# Altium schematic sheet pt_boy_revB.SchDoc
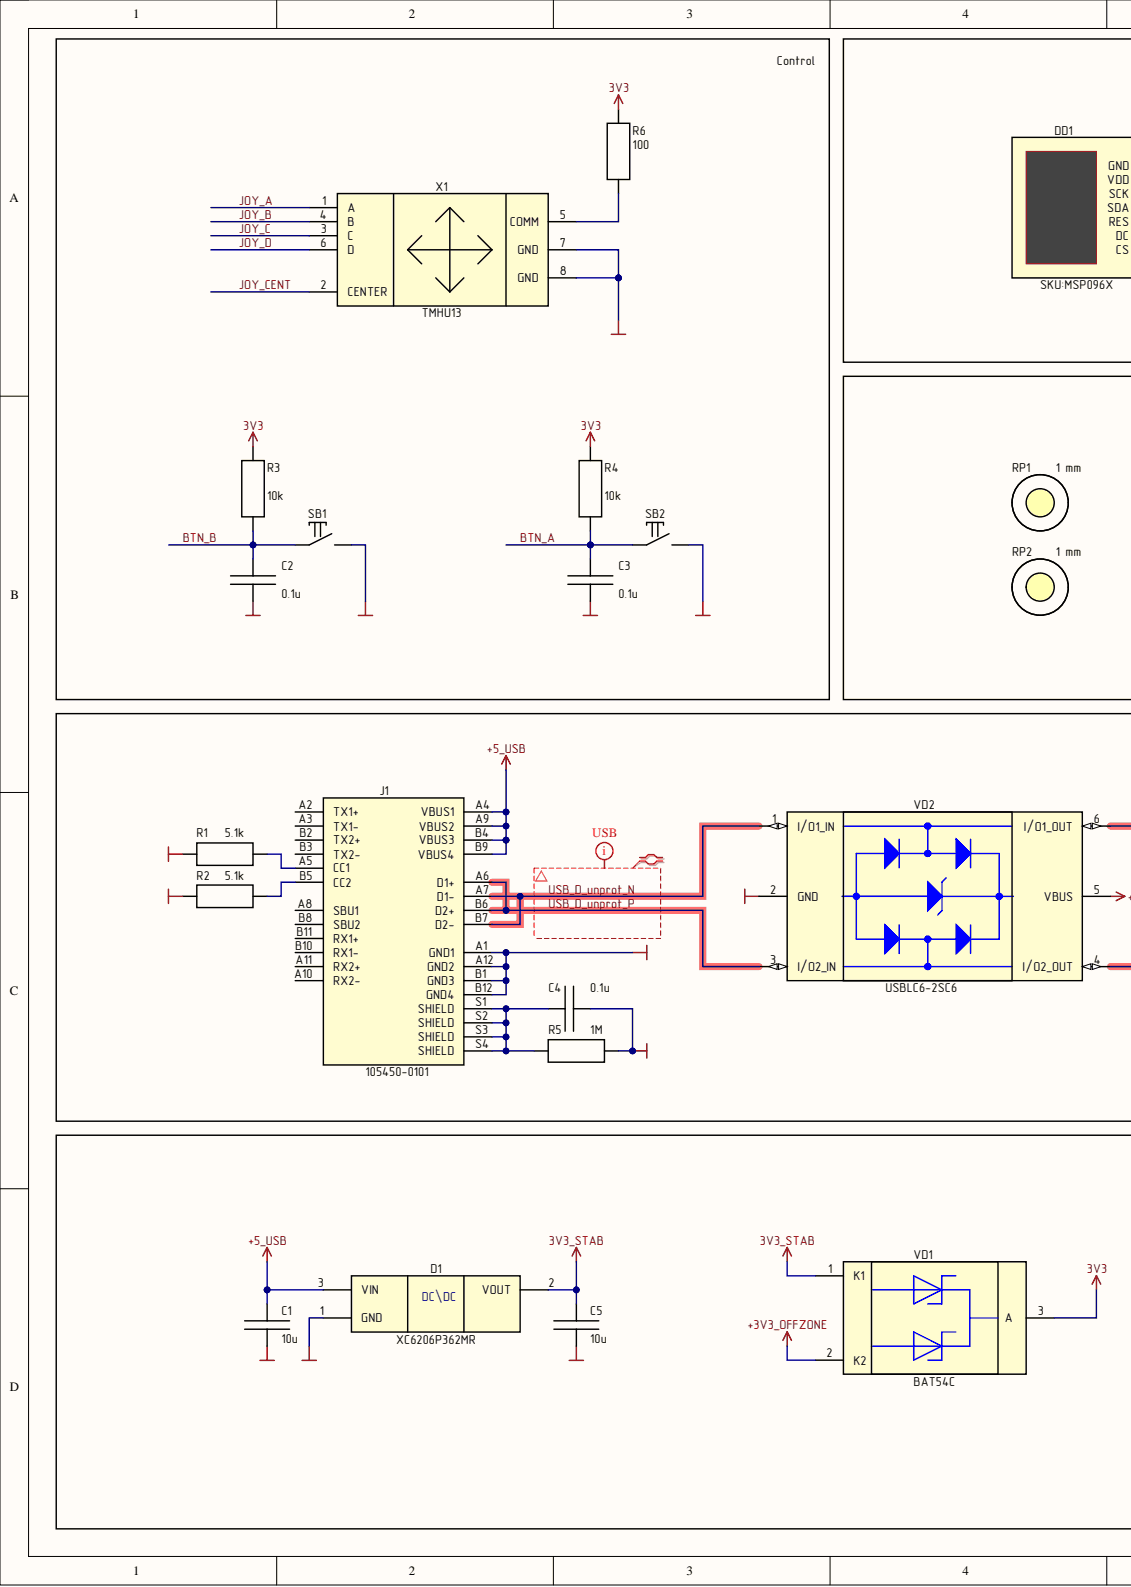
[diagram p0: pt_boy_revB.SchDoc, left]
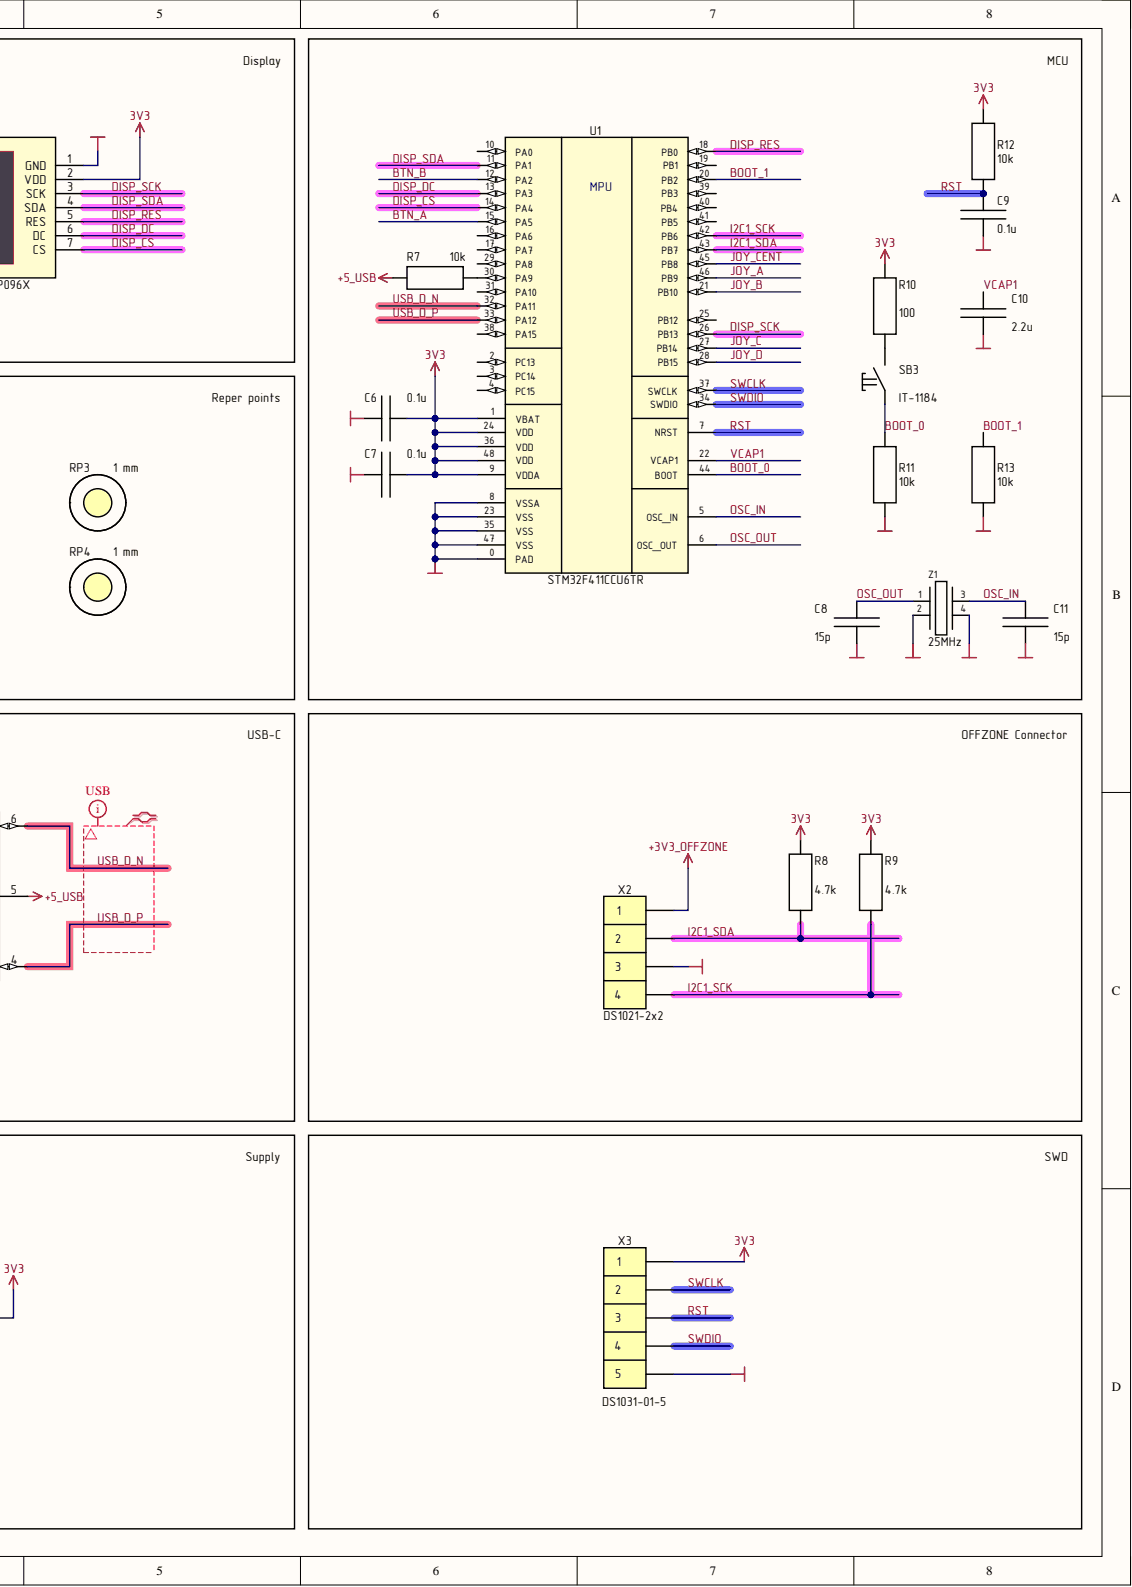
[diagram p1: pt_boy_revB.SchDoc, right]

## Parts
C1  10u  [CH0603C]
C2  0.1u  [CH0603C]
C3  0.1u  [CH0603C]
C4  0.1u  [CH0603C]
C5  10u  [CH0603C]
C6  0.1u  [CH0603C]
C7  0.1u  [CH0603C]
C8  15p  [CH0603C]
C9  0.1u  [CH0603C]
C10  2.2u  [CH0603C]
C11  15p  [CH0603C]
D1  XC6206P362MR  [SOT23_132]
DD1  SKU:MSP096X  [OLED I2C and SPI]
J1  105450-0101  [MOLEX_105450-0101]
R1  5.1k  [CH0603R]
R2  5.1k  [CH0603R]
R3  10k  [CH0603R]
R4  10k  [CH0603R]
R5  1M  [CH0603R]
R6  100  [CH0603R]
R7  10k  [CH0603R]
R8  4.7k  [CH0603R]
R9  4.7k  [CH0603R]
R10  100  [CH0603R]
R11  10k  [CH0603R]
R12  10k  [CH0603R]
R13  10k  [CH0603R]
RP1  1 mm  [REPER-10]  (no BOM)
RP2  1 mm  [REPER-10]  (no BOM)
RP3  1 mm  [REPER-10]  (no BOM)
RP4  1 mm  [REPER-10]  (no BOM)
SB1  BTN_B  [DTSM-62]  (value not drawn)
SB2  BTN_A  [DTSM-62]  (value not drawn)
SB3  BOOT  [IT-1184]
U1  IC microcontroller, 48-UFQFPN (7x7), 32-Bit, Cortex-M4, 256KB, 128KB, 100MHz, 2...3.6V, -40...+85°C  [BODY: UFQFPN48 (7x7) - via 0.2mm]  (value not drawn)
VD1  BAT54C  [SOT23_132]
VD2  USBLC6-2SC6  [SOT95P280X145-6N]
X1  TMHU13  [TMHU13]
X2  DS1021-2x2  [DS1021-2x2]
X3  DS1031-01-5  [DS1031-01-5]  (no BOM)
Z1  Frequency: 25MHz  [OSC: 3225]  (hidden comment: Crystal, case 3225, 25MHz, 12pF, ±30ppm, -40...+85°C)

## Nets
3V3: C6.2 C7.2 DD1.2(VDD) R3.2 R4.2 R6.2 R8.2 R9.2 R10.2 R12.2 U1.1(VBAT) U1.9(VDDA) U1.24(VDD) U1.36(VDD) U1.48(VDD) VD1.2 VD1.3 X2.1 X3.1
3V3_STAB: C5.2 D1.2 VD1.1
+5_USB: C1.2 D1.3 J1.A4(VBUS1) J1.A9(VBUS2) J1.B4(VBUS3) J1.B9(VBUS4) R7.2 VD2.5(VBUS)
GND: C1.1 C2.1 C3.1 C4.1 C5.1 C6.1 C7.1 C8.1 C9.1 C10.1 C11.1 D1.1 DD1.1(GND) J1.A1(GND1) J1.A12(GND2) J1.B1(GND3) J1.B12(GND4) R1.1 R2.1 R5.2 R11.1 R13.1 SB1.2 SB2.2 U1.0(PAD) U1.8(VSSA) U1.23(VSS) U1.35(VSS) U1.47(VSS) VD2.2(GND) X1.7(GND) X1.8(GND) X2.3 X3.5 Z1.2 Z1.4
BOOT_0: R11.2 SB3.1 U1.44(BOOT)
BOOT_1: R13.2 U1.20(PB2)
BTN_A: C3.2 R4.1 SB2.1 U1.15(PA5)
BTN_B: C2.2 R3.1 SB1.1 U1.12(PA2)
DISP_CS: DD1.7(CS) U1.14(PA4)
DISP_DC: DD1.6(DC) U1.13(PA3)
DISP_RES: DD1.5(RES) U1.18(PB0)
DISP_SCK: DD1.3(SCK) U1.26(PB13)
DISP_SDA: DD1.4(SDA) U1.11(PA1)
I2C1_SCK: R9.1 U1.42(PB6) X2.4
I2C1_SDA: R8.1 U1.43(PB7) X2.2
JOY_A: U1.46(PB9) X1.1(A)
JOY_B: U1.21(PB10) X1.4(B)
JOY_C: U1.27(PB14) X1.3(C)
JOY_CENT: U1.45(PB8) X1.2(CENTER)
JOY_D: U1.28(PB15) X1.6(D)
OSC_IN: C11.2 U1.5(OSC_IN) Z1.3
OSC_OUT: C8.2 U1.6(OSC_OUT) Z1.1
RST: C9.2 R12.1 U1.7(NRST) X3.3
SWCLK: U1.37(SWCLK) X3.2
SWDIO: U1.34(SWDIO) X3.4
USB_D_N: U1.32(PA11) VD2.6(I/O1_OUT)
USB_D_P: U1.33(PA12) VD2.4(I/O2_OUT)
USB_D_unprot_N: J1.A7(D1-) J1.B7(D2-) VD2.1(I/O1_IN)
USB_D_unprot_P: J1.A6(D1+) J1.B6(D2+) VD2.3(I/O2_IN)
VCAP1: C10.2 U1.22(VCAP1)
NetC4_2: C4.2 J1.S1(SHIELD) J1.S2(SHIELD) J1.S3(SHIELD) J1.S4(SHIELD) R5.1
NetJ1_A5: J1.A5(CC1) R1.2
NetJ1_B5: J1.B5(CC2) R2.2
NetR6_1: R6.1 X1.5(COMM)
NetR7_1: R7.1 U1.30(PA9)
NetR10_1: R10.1 SB3.2

Not connected: J1 A2(TX1+) A3(TX1-) A8(SBU1) A10(RX2-) A11(RX2+) B2(TX2+) B3(TX2-) B8(SBU2) B10(RX1-) B11(RX1+); RP1 1; RP2 1; RP3 1; RP4 1; U1 2(PC13) 3(PC14) 4(PC15) 10(PA0) 16(PA6) 17(PA7) 19(PB1) 25(PB12) 29(PA8) 31(PA10) 38(PA15) 39(PB3) 40(PB4) 41(PB5)

## Library data of the parts
C1 C5 = Capacitor: PartNumber: GRM188R60J106ME47D; Description/Value: Конденсатор керамический smd 10 мкФ X5R 20% 6.3В 0603; Component Kind: Standard; Library Name: OLED.SchLib
C2-C4 C6 C7 C9 = Capacitor: PartNumber: GRM188R71H104K; Description/Value: Конденсатор керамический smd 0.1мкФ X7R 50В 10% 0603; Component Kind: Standard; Library Name: OLED.SchLib
C8 C11 = Capacitor: PartNumber: GRM1885C2A150J; Description/Value: Конденсатор керамический smd 15пФ NPO 100В 5%0603; Component Kind: Standard; Library Name: OLED.SchLib
C10 = Capacitor: PartNumber: GRM188R61A225K; Description/Value: Конденсатор керамический smd 2.2мкФ,X5R,10% 10В 0603; Value: GRM188R61A225K; Component Kind: Standard; Library Name: OLED.SchLib
D1 = XC6206P362MR: LDO; Manufacturer: Texas Instruments; Description/Value: LDO стабилизатор напряжения, +3.6В, 200мА [SOT-23-3]; Model: TLV809K33DBVR; Component Kind: Standard; ComponentKind: SOT23; HelpURL: https://www.digikey.com/product-detail/en/texas-instruments/TLV809K33DBVR/296-39291-1-ND/5143282; Library Name: OLED.SchLib; PartNumber: XC6206P362MR
DD1 = OLED 0,96 SPI and I2C: Description/Value: Дисплей OLED 0,96 I2C SPI; Component Kind: Standard; Library Name: OLED.SchLib; PartNumber: SKU:MSP096X
J1 = 105450-0101: USB-C (USB TYPE-C) USB 3.2 Gen 2 (USB 3.1 Gen 2, Superspeed + (USB 3.1)) Receptacle Connector 24 Position Surface Mount, Right Angle; Through Hole; Description/Value: Разъем USB-C гнездо угловое 24pin SMD USB 3.1; MF: Molex; Availability: In Stock; Check_prices: https://www.snapeda.com/parts/105450-0101/Molex/view-part/?ref=eda; Component Kind: Standard; Library Name: 105450-0101.SchLib; MP: 105450-0101; Package: None; PartNumber: 105450-0101; Price: None; Purchase-URL: https://pricing.snapeda.com/search/part/105450-0101/?ref=eda; SnapEDA_Link: https://www.snapeda.com/parts/105450-0101/Molex/view-part/?ref=snap
R1 R2 = Resistor: PartNumber: RC0603FR-075K1L; Description/Value: 0.1Вт 0603 5.1 кОм, 5%, Чип резистор (SMD); Component Kind: Standard; Library Name: OLED.SchLib
R3 R4 R7 R11-R13 = Resistor: PartNumber: RC0603FR-0710KL; Description/Value: 0.1Вт 0603 10 кОм, 5%, Чип резистор (SMD); Component Kind: Standard; Library Name: OLED.SchLib
R5 = Resistor: PartNumber: RC0603FR-071ML; Description/Value: 0.1Вт 0603 1 МОм, 5%, Чип резистор (SMD); Component Kind: Standard; Library Name: OLED.SchLib
R6 R10 = Resistor: PartNumber: RC0603FR-07100RL; Description/Value: 0.1Вт 0603 100 Ом, 5%, Чип резистор (SMD); Component Kind: Standard; Library Name: OLED.SchLib
R8 R9 = Resistor: PartNumber: RC0603FR-074K7L; Description/Value: 0.1Вт 0603 4.7 кОм, 5%, Чип резистор (SMD); Component Kind: Standard; Library Name: OLED.SchLib
RP1-RP4 = REPER: Library Name: Common Lib.SchLib; Value: 1 mm
SB1 SB2 = Tactile switches: 6x6 mm; PartNumber: DTSM-62R; Description/Value: Кнопка тактовая, поверхностный монтаж, красный колпачок; Component Kind: Standard; Library Name: OLED.SchLib
SB3 = Tactile switches: Description/Value: Tactile switch 3x3, IT-1184; Component Kind: Standard; Library Name: OLED.SchLib; PartNumber: IT-1184
U1 = STM32F411CCU6TR: Cortex-M4; Manufacturer: STMicroelectronics; Component Kind: Standard; ComponentType: IC microcontroller; CoreProcessor: Cortex-M4; CoreSize: 32-Bit; Description/Value: IC microcontroller, 48-UFQFPN (7x7), 32-Bit, Cortex-M4, 256KB, 128KB, 100MHz, 2...3.6V, -40...+85°C; HelpURL: https://www.digikey.com/en/products/detail/stmicroelectronics/STM32F411CCU6TR/6166917; Library Name: OLED.SchLib; MemoryRAM: 128KB; MemoryROM: 256KB; Package: 48-UFQFPN (7x7); PartNumber: STM32F411CCU6TR; Speed: 100MHz; Temperature: -40...+85°C; Type: SMD; VoltageInput: 2...3.6V
VD1 = Diod Common Anod: Description/Value: Диод Шоттки, 2 диода, общий катод, [SOT-23]; Component Kind: Standard; Library Name: OLED.SchLib; PartNumber: BAT54C
VD2 = USBLC6-2SC6: 17V Clamp 5A (8/20Âµs) Ipp Tvs Diode Surface Mount SOT-23-6; Description/Value: Устройство защиты USB от ESD, сборка защитных диодов, 5В [SOT-23-6]; MF: STMicroelectronics; Availability: In Stock; Check_prices: https://www.snapeda.com/parts/USBLC6-2SC6/STMicroelectronics/view-part/?ref=eda; Component Kind: Standard; Library Name: OLED.SchLib; MP: USBLC6-2SC6; Package: SOT-23-6 STMicroelectronics; PartNumber: USBLC6-2SC6; Price: None; Purchase-URL: https://pricing.snapeda.com/search/part/USBLC6-2SC6/?ref=eda; SnapEDA_Link: https://www.snapeda.com/parts/USBLC6-2SC6/STMicroelectronics/view-part/?ref=snap
X1 = TMHU13: Description/Value: Микроджойстик поверхностный; Component Kind: Standard; Library Name: OLED.SchLib; PartNumber: TMHU13
X2 = 4-Connector: Description/Value: Connector 4 pin PLD-4; Component Kind: Standard; ComponentKind: Connector 4 pin PLD-4; Library Name: Common Lib.SchLib; PartNumber: DS1021-2x2
X3 = 5-Connector: Library Name: Common Lib.SchLib; PartNumber: DS1031-01-5
Z1 = Crystal_3225_25MHz: Manufacturer: Geyer; PartNumber: KSE-7U25000MAF143ZA3; Component Kind: Standard; ComponentType: Crystal; Description/Value: Crystal, case 3225, 25MHz, 12pF, ±30ppm, -40...+85°C; Library Name: OLED.SchLib; LoadCapacitance: 12pF; Package: case 3225; Temperature: -40...+85°C; Tolerance: ±30ppm; Type: SMD
